# Altium schematic sheet power.SchDoc(power)
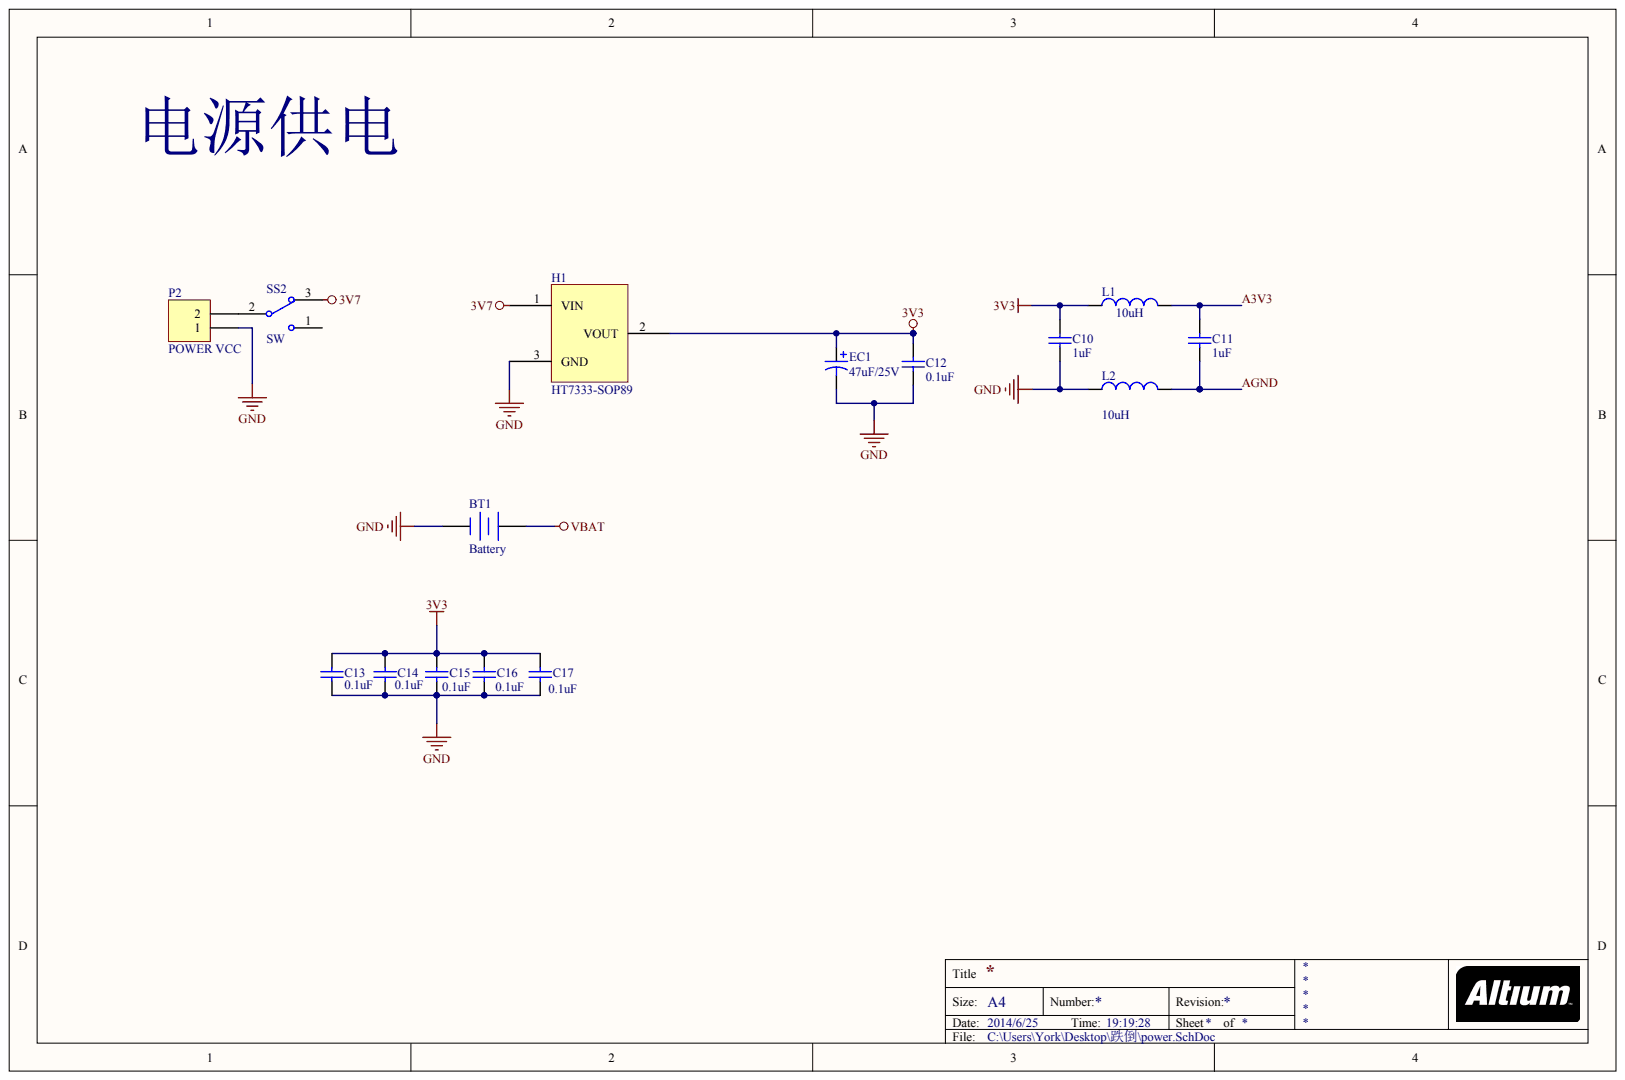
[diagram p0: power.SchDoc]

## Parts
BT1  Battery
C10  1uF
C11  1uF
C12  value 0.1uF  (hidden comment: Cap)
C13  value 0.1uF  (hidden comment: Cap)
C14  value 0.1uF  (hidden comment: Cap)
C15  value 0.1uF  (hidden comment: Cap)
C16  value 0.1uF  (hidden comment: Cap)
C17  value 0.1uF  (hidden comment: Cap)
EC1  47uF/25V
H1  HT7333-SOP89
L1  10uH
L2  10uH
P2  POWER VCC
SS2  SW

## Nets
3V3: C10.2 C12.1 C13.2 C14.2 C15.2 C16.2 C17.2 EC1.1 H1.2 L1.1
3V7: H1.1 SS2.3
GND: BT1.2 C10.1 C12.2 C13.1 C14.1 C15.1 C16.1 C17.1 EC1.2 H1.3 L2.1 P2.1
VBAT: BT1.1
A3V3: C11.2 L1.2
AGND: C11.1 L2.2
NetP2_2: P2.2 SS2.2

Not connected: SS2 1

## Library data of the parts
C10 C11 = (no symbol name): Value: 0.01uF; Published: 8-Jun-2000; LatestRevisionDate: 17-Jul-2002; LatestRevisionNote: Re-released for DXP Platform.; PackageReference: RAD-0.3; Publisher: Altium Limited
EC1 = (no symbol name): Value: 47uF; Published: 8-Jun-2000; LatestRevisionDate: 17-Jul-2002; LatestRevisionNote: Re-released for DXP Platform.; PackageReference: RB7.6-15; PackageDescription: Polarized Capacitor (Radial); 2 Leads; Publisher: Altium Limited
L1 L2 = (no symbol name): Code_IEC: 1005; Published: 8-Jun-2000; LatestRevisionDate: 17-Jul-2002; LatestRevisionNote: Re-released for DXP Platform.; PackageReference: 0402-A; Code_JEITA: 0402; Value: 10uH; Publisher: Altium Limited
BT1 C12-C17 H1 P2 SS2 = (no symbol name)
  BT1: Published: 24-Mar-1999; LatestRevisionDate: 17-Jul-2002; LatestRevisionNote: Re-released for DXP Platform.; PackageReference: BAT-2; PackageDescription: Battery; 2 Leads
  C12-C17: Published: 8-Jun-2000; LatestRevisionDate: 17-Jul-2002; LatestRevisionNote: Re-released for DXP Platform.; PackageReference: RAD-0.3; PackageDescription: Radial Cap, Thru-Hole; 2 Leads; 0.3 in Pin Spacing
  P2: LatestRevisionDate: 17-Jul-2002; LatestRevisionNote: Re-released for DXP Platform.
  BT1 C12-C17 P2: Publisher: Altium Limited
